# Altium schematic sheet CV210506.SchDoc
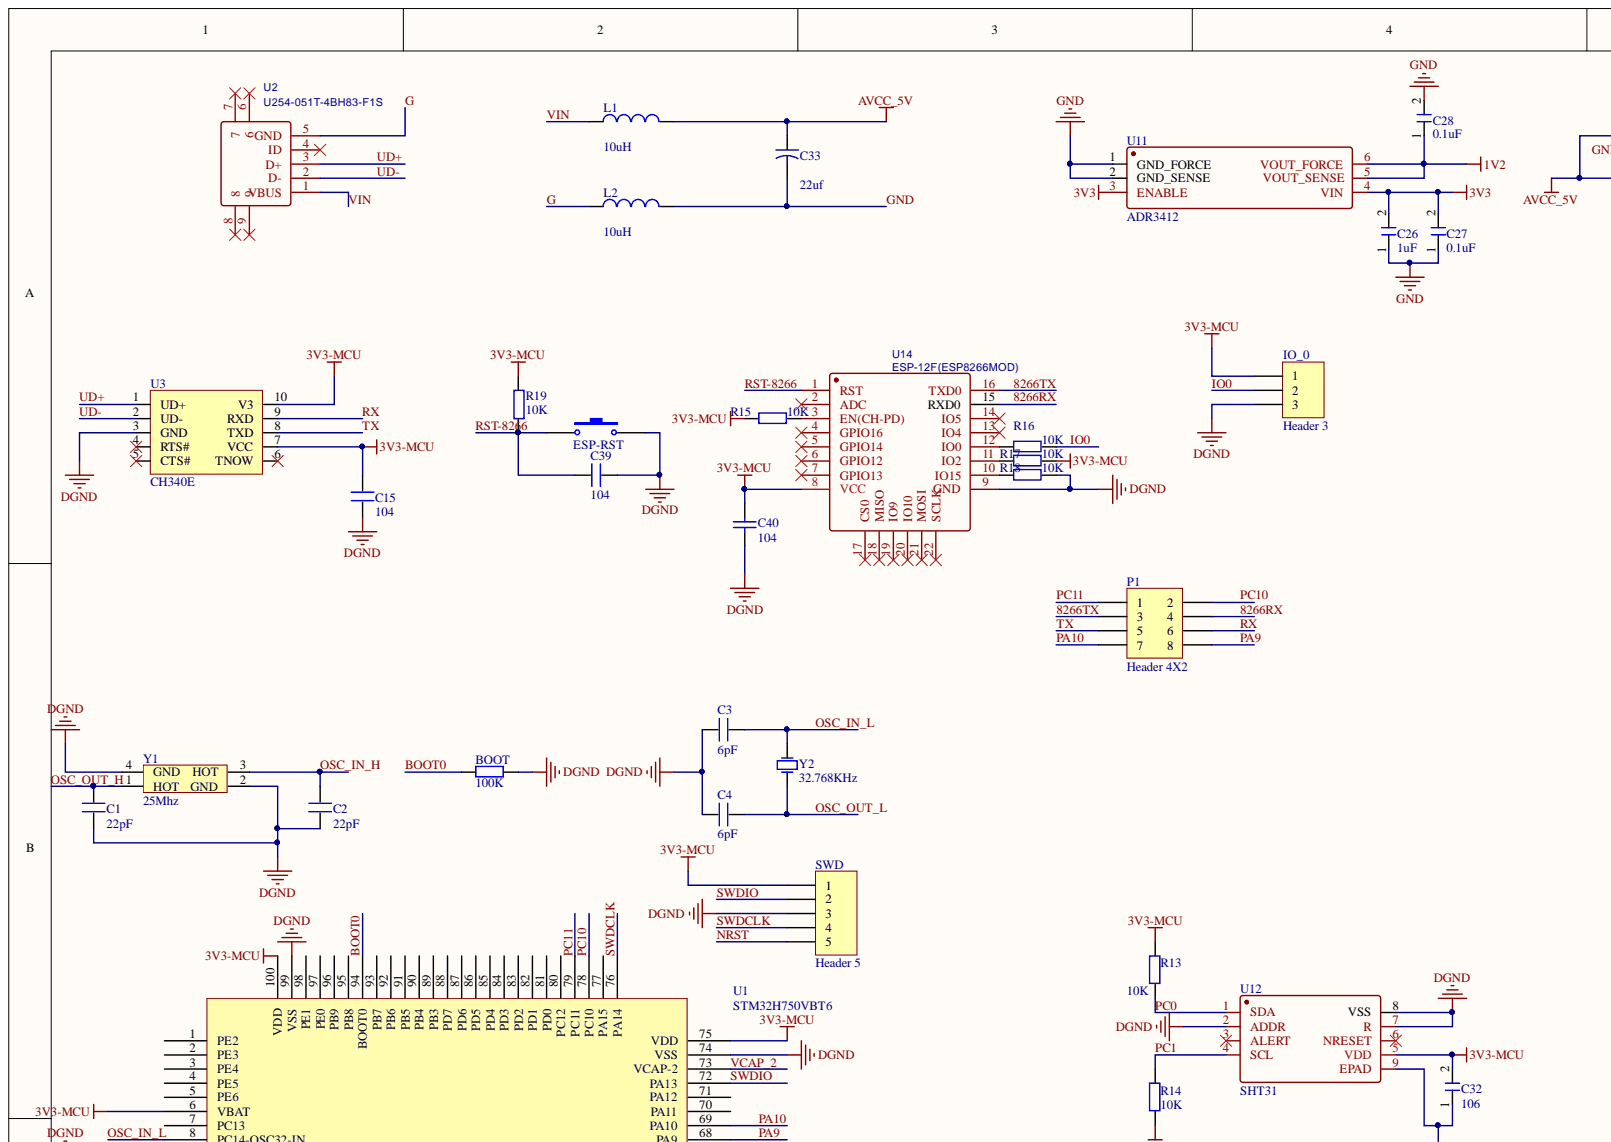
[diagram p0: CV210506.SchDoc, top left]
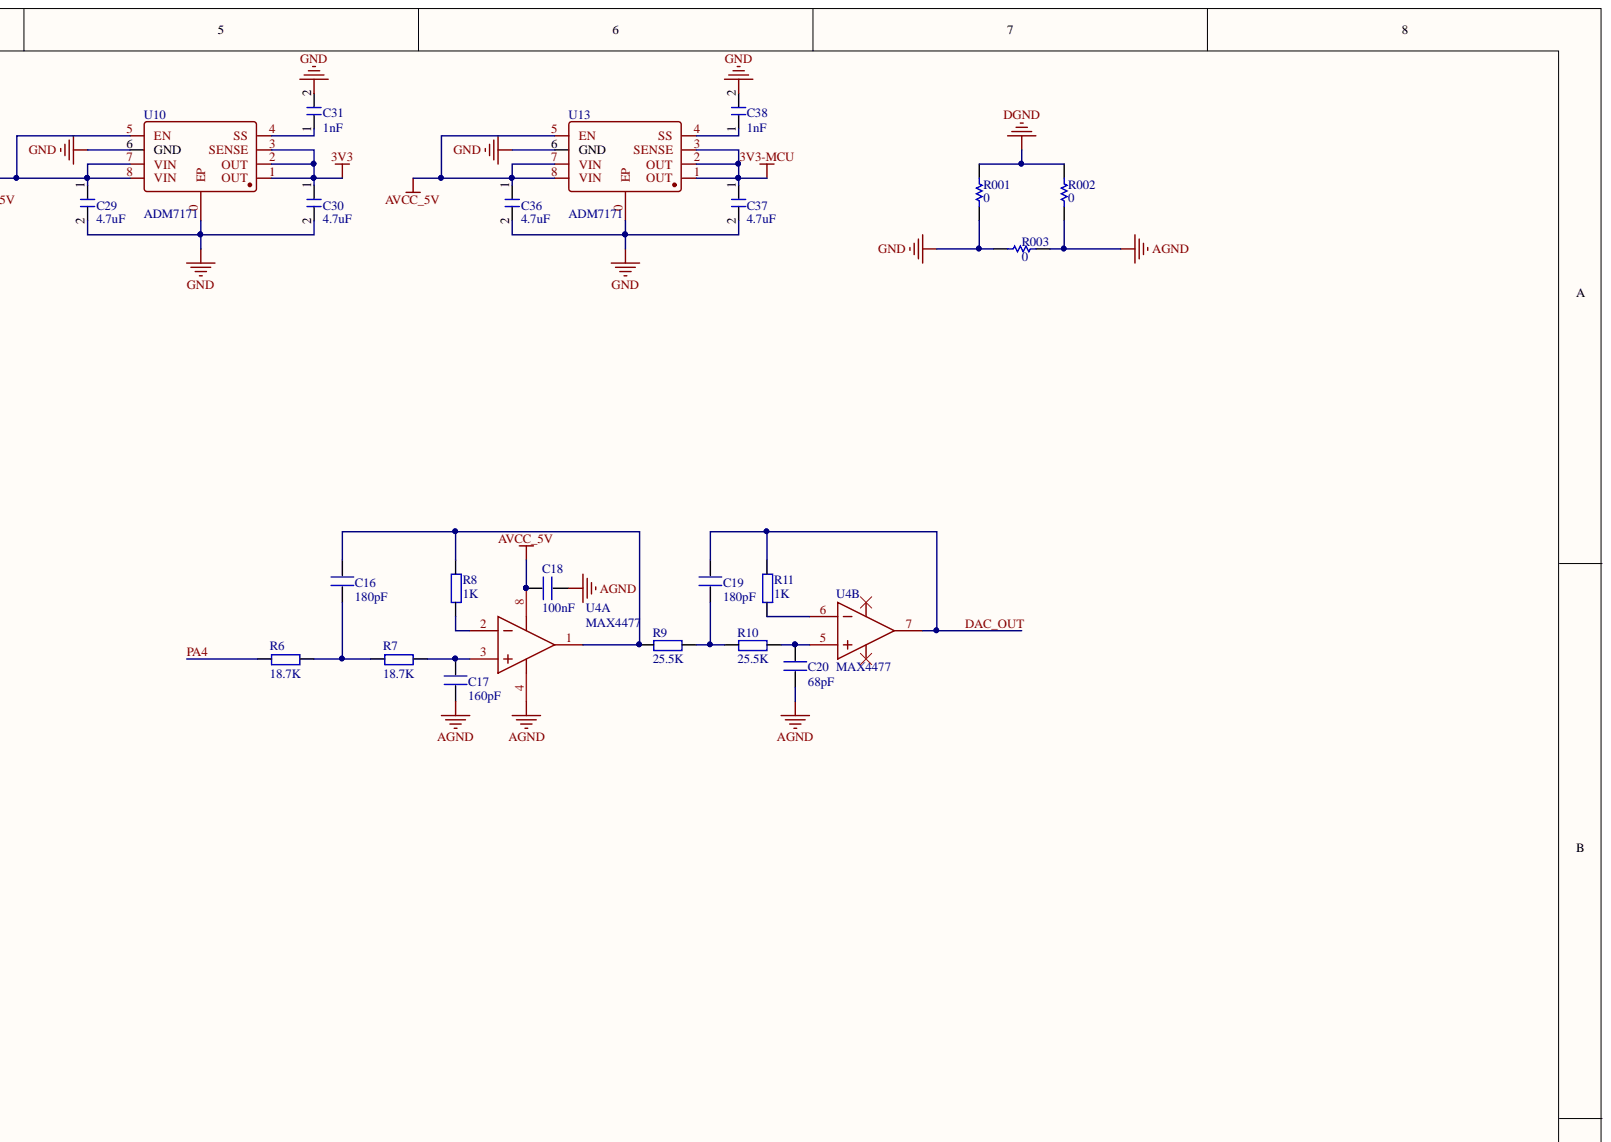
[diagram p1: CV210506.SchDoc, top right]
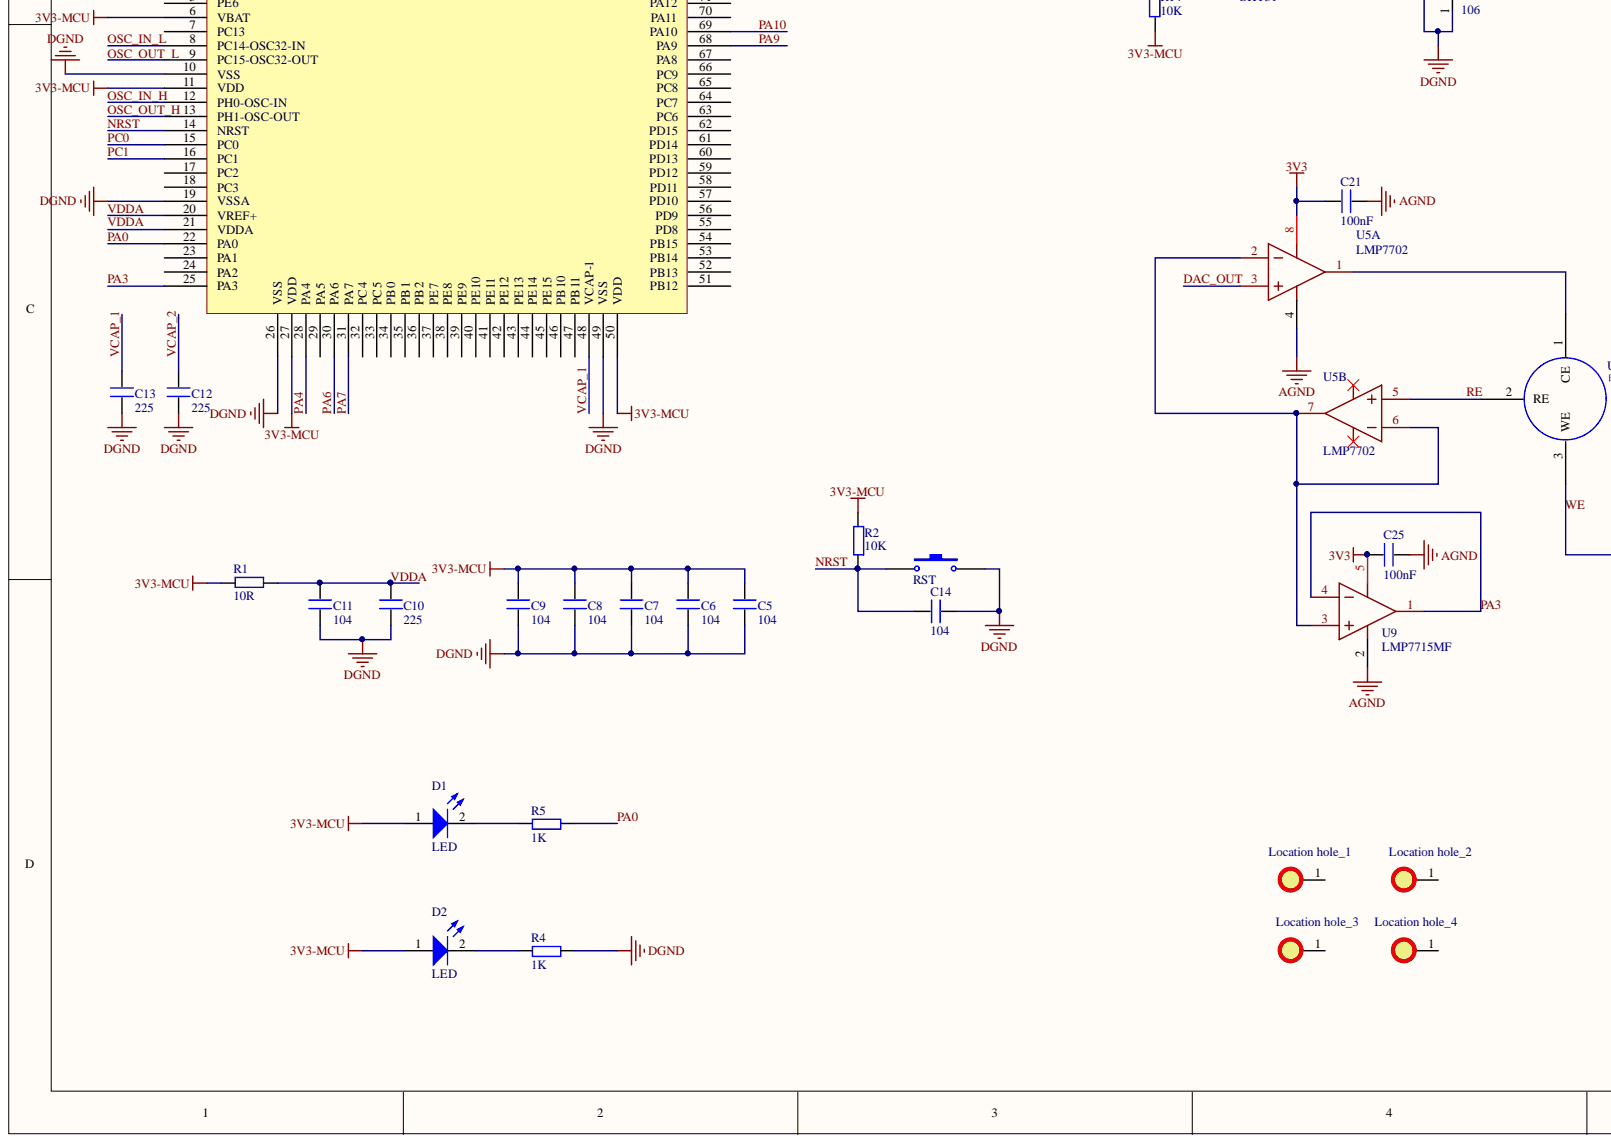
[diagram p2: CV210506.SchDoc, bottom left]
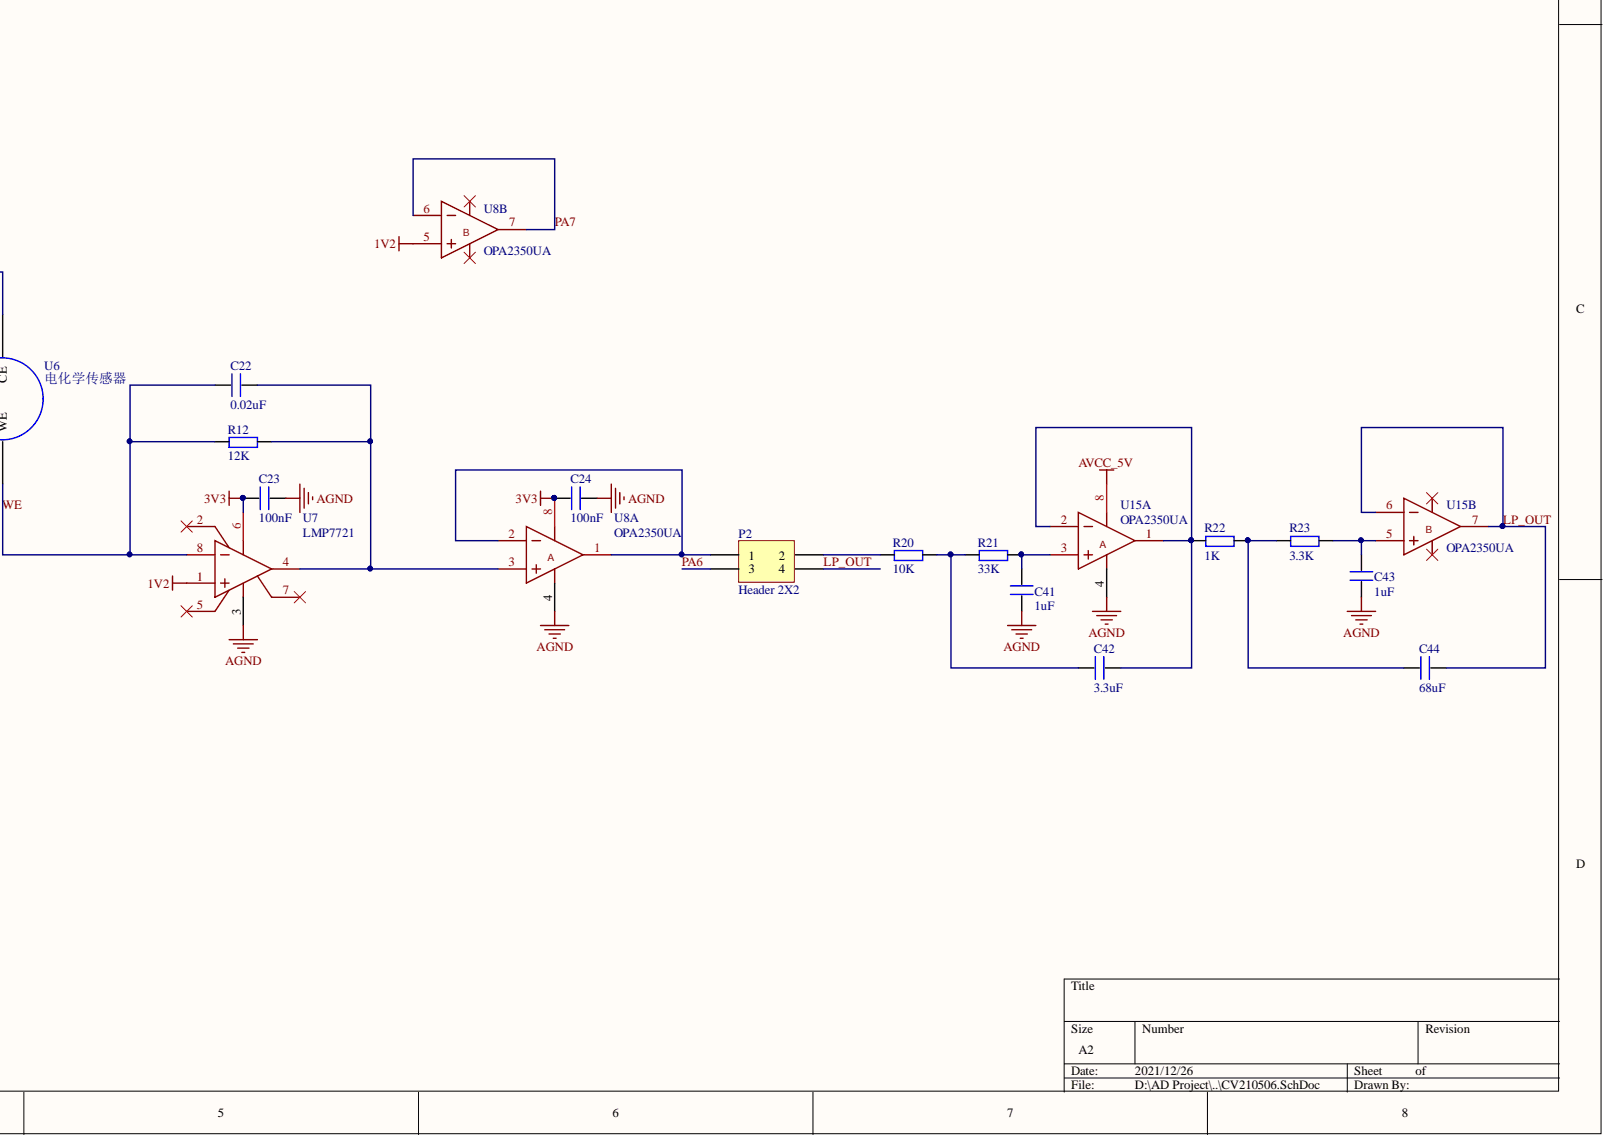
[diagram p3: CV210506.SchDoc, bottom right]

## Parts
BOOT  100K  [RES_0402]
C1  22pF  [CAP_0402]
C2  22pF  [CAP_0402]
C3  6pF  [CAP_0402]
C4  6pF  [CAP_0402]
C5  104  [CAP_0402]
C6  104  [CAP_0402]
C7  104  [CAP_0402]
C8  104  [CAP_0402]
C9  104  [CAP_0402]
C10  225  [CAP_0402]
C11  104  [CAP_0402]
C12  225  [CAP_0402]
C13  225  [CAP_0402]
C14  104  [CAP_0402]
C15  104  [CAP_0402]
C16  180pF  [CAP_0402]
C17  160pF  [CAP_0402]
C18  100nF  [CAP_0402]
C19  180pF  [CAP_0402]
C20  68pF  [CAP_0402]
C21  100nF  [CAP_0402]
C22  0.02uF  [CAP_0402]
C23  100nF  [CAP_0402]
C24  100nF  [CAP_0402]
C25  100nF  [CAP_0402]
C26  1uF  [CAP_0402]
C27  0.1uF  [CAP_0402]
C28  0.1uF  [CAP_0402]
C29  4.7uF  [CAP_0402]
C30  4.7uF  [CAP_0402]
C31  1nF  [CAP_0402]
C32  106  [CAP_0402]
C33  value 22uf  [CAP_0805]  (hidden comment: Cap2)
C36  4.7uF  [CAP_0402]
C37  4.7uF  [CAP_0402]
C38  1nF  [CAP_0402]
C39  104  [CAP_0402]
C40  104  [CAP_0402]
C41  1uF  [CAP_0402]
C42  3.3uF  [CAP_0402]
C43  1uF  [CAP_0402]
C44  68uF  [CAP_0402]
D1  LED  [DIODE_0603]
D2  LED  [DIODE_0603]
ESP-RST  SW-PB  [key3*3]  (value not drawn)
IO_0  Header 3  [HDR1X3]
L1  value 10uH  [RES_1206]  (hidden comment: Inductor)
L2  value 10uH  [RES_1206]  (hidden comment: Inductor)
Location_hole_1  Touch  [kong 3.5]  (value not drawn)
Location_hole_2  Touch  [kong 3.5]  (value not drawn)
Location_hole_3  Touch  [kong 3.5]  (value not drawn)
Location_hole_4  Touch  [kong 3.5]  (value not drawn)
P1  Header 4X2  [HDR2X4]
P2  Header 2X2  [HDR2X2]
R001  0  [RES_0402]
R1  10R  [RES_0402]
R002  0  [RES_0402]
R2  10K  [RES_0402]
R003  0  [RES_0402]
R4  1K  [RES_0402]
R5  1K  [RES_0402]
R6  18.7K  [RES_0402]
R7  18.7K  [RES_0402]
R8  1K  [RES_0402]
R9  25.5K  [RES_0402]
R10  25.5K  [RES_0402]
R11  1K  [RES_0402]
R12  12K  [RES_0805]
R13  10K  [RES_0402]
R14  10K  [RES_0402]
R15  value 10K  [RES_0402]  (hidden comment: Res2)
R16  value 10K  [RES_0402]  (hidden comment: Res2)
R17  value 10K  [RES_0402]  (hidden comment: Res2)
R18  value 10K  [RES_0402]  (hidden comment: Res2)
R19  10K  [RES_0402]
R20  10K  [RES_0402]
R21  33K  [RES_0402]
R22  1K  [RES_0402]
R23  3.3K  [RES_0402]
RST  SW-PB  [key3*3]  (value not drawn)
SWD  Header 5  [HDR1X5]
U1  STM32H750VBT6  [LQFP-100]
U2  U254-051T-4BH83-F1S  [USB]
U3  CH340E  [MSOP-10_L3.0-W3.0-P0.50-LS5.0-BL]
U4  MAX4477  [uMAX-8]  (parts A,B)
U5  LMP7702  [SOIC-8]  (parts A,B)
U6  电化学传感器  [HDR1X3]
U7  LMP7721  [SOIC-8]
U8  OPA2350UA  [VSSOP-8 - 2350]  (parts A,B)
U9  LMP7715MF  [SOT-23-5]
U10  ADM7171  [LFCSP-8]
U11  ADR3412  [SOT-23-6]
U12  SHT31  [DFN-8_EP_3x3mm]
U13  ADM7171  [LFCSP-8]
U14  ESP-12F(ESP8266MOD)  [ESP8266]
U15  OPA2350UA  [VSSOP-8 - 2350]  (parts A,B)
Y1  25Mhz  [SMD 3225]
Y2  32.768KHz  [SMD 3215-2P 32.768K]

## Nets
1V2: C28.1 U7.1 U8.5 U11.5(VOUT_SENSE) U11.6(VOUT_FORCE)
3V3: C21.2 C23.2 C24.2 C25.2 C26.2 C27.2 C30.1 U5.8 U7.6 U8.8 U9.5 U10.1(OUT) U10.2(OUT) U10.3(SENSE) U11.3(ENABLE) U11.4(VIN)
3V3-MCU: C5.2 C6.2 C7.2 C8.2 C9.2 C15.1 C32.2 C37.1 C40.1 D1.1 D2.1 IO_0.1 R1.2 R2.2 R13.2 R14.1 R15.1 R17.2 R19.2 SWD.1 U1.6(VBAT) U1.11(VDD) U1.27(VDD) U1.50 U1.75(VDD) U1.100(VDD) U3.7(VCC) U3.10(V3) U12.5(VDD) U13.1(OUT) U13.2(OUT) U13.3(SENSE) U14.8(VCC)
AGND: C17.1 C18.1 C20.1 C21.1 C23.1 C24.1 C25.1 C41.1 C43.1 R002.2 R003.1 U4.4 U5.4 U7.3 U8.4 U9.2 U15.4
AVCC_5V: C18.2 C29.1 C33.1 C36.1 L1.2 U4.8 U10.5(EN) U10.7(VIN) U10.8(VIN) U13.5(EN) U13.7(VIN) U13.8(VIN) U15.8
DGND: BOOT.2 C1.1 C2.1 C3.1 C4.1 C5.1 C6.1 C7.1 C8.1 C9.1 C10.1 C11.1 C12.1 C13.1 C14.2 C15.2 C32.1 C39.2 C40.2 ESP-RST.2 IO_0.3 R001.1 R002.1 R4.2 R18.2 RST.2 SWD.3 U1.10(VSS) U1.19(VSSA) U1.26(VSS) U1.49(VSS) U1.74(VSS) U1.99(VSS) U3.3(GND) U12.2(ADDR) U12.7(R) U12.8(VSS) U12.9(EPAD) U14.9(GND) Y1.2(GND) Y1.4(GND)
8266RX: P1.4 U14.15(RXD0)
8266TX: P1.3 U14.16(TXD0)
BOOT0: BOOT.1 U1.94(BOOT0)
DAC_OUT: C19.2 R11.2 U4.7 U5.3
G: L2.1 U2.5(GND)
GND: C26.1 C27.1 C28.2 C29.2 C30.2 C31.2 C33.2 C36.2 C37.2 C38.2 L2.2 R001.2 R003.2 U10.0(EP) U10.6(GND) U11.1(GND_FORCE) U11.2(GND_SENSE) U13.0(EP) U13.6(GND)
IO0: IO_0.2 R16.2
LP_OUT: C44.1 P2.4 U15.6 U15.7
NRST: C14.1 R2.1 RST.1 SWD.5 U1.14(NRST)
OSC_IN_H: C2.2 U1.12(PH0-OSC-IN) Y1.3(HOT)
OSC_IN_L: C3.2 U1.8(PC14-OSC32-IN) Y2.2
OSC_OUT_H: C1.2 U1.13(PH1-OSC-OUT) Y1.1(HOT)
OSC_OUT_L: C4.2 U1.9(PC15-OSC32-OUT) Y2.1
PA0: R5.2 U1.22(PA0)
PA3: U1.25(PA3) U9.1 U9.4
PA4: R6.1 U1.28(PA4)
PA6: P2.3 U1.30(PA6)
PA7: U1.31(PA7) U8.6 U8.7
PA9: P1.8 U1.68(PA9)
PA10: P1.7 U1.69(PA10)
PC0: R13.1 U1.15(PC0) U12.1(SDA)
PC1: R14.2 U1.16(PC1) U12.4(SCL)
PC10: P1.2 U1.78(PC10)
PC11: P1.1 U1.79(PC11)
RE: U5.5 U6.2
RST-8266: C39.1 ESP-RST.1 R19.1 U14.1(RST)
RX: P1.6 U3.9(RXD)
SWDCLK: SWD.4 U1.76
SWDIO: SWD.2 U1.72(PA13)
TX: P1.5 U3.8(TXD)
UD+: U2.3(D+) U3.1(UD+)
UD-: U2.2(D-) U3.2(UD-)
VCAP_1: C13.2 U1.48(VCAP-1)
VCAP_2: C12.2 U1.73(VCAP-2)
VDDA: C10.2 C11.2 R1.1 U1.20(VREF+) U1.21(VDDA)
VIN: L1.1 U2.1(VBUS)
WE: C22.2 R12.1 U6.3 U7.8
NetC16_1: C16.1 R6.2 R7.1
NetC16_2: C16.2 R8.2 R9.1 U4.1
NetC17_2: C17.2 R7.2 U4.3
NetC19_1: C19.1 R9.2 R10.1
NetC20_2: C20.2 R10.2 U4.5
NetC22_1: C22.1 R12.2 U7.4 U8.3
NetC31_1: C31.1 U10.4(SS)
NetC38_1: C38.1 U13.4(SS)
NetC41_2: C41.2 R21.2 U15.3
NetC42_1: C42.1 R22.1 U15.1 U15.2
NetC42_2: C42.2 R20.2 R21.1
NetC43_2: C43.2 R23.2 U15.5
NetC44_2: C44.2 R22.2 R23.1
NetD1_2: D1.2 R5.1
NetD2_2: D2.2 R4.1
NetP2_1: P2.1 U8.1 U8.2
NetP2_2: P2.2 R20.1
NetR8_1: R8.1 U4.2
NetR11_1: R11.1 U4.6
NetR15_2: R15.2 U14.3(EN(CH-PD))
NetR16_1: R16.1 U14.12(IO0)
NetR17_1: R17.1 U14.11(IO2)
NetR18_1: R18.1 U14.10(IO15)
NetU5_1: U5.1 U6.1
NetU5_2: U5.2 U5.6 U5.7 U9.3

Not connected: Location_hole_1 1; Location_hole_2 1; Location_hole_3 1; Location_hole_4 1; U1 1(PE2) 2(PE3) 3(PE4) 4(PE5) 5(PE6) 7(PC13) 17(PC2) 18(PC3) 23(PA1) 24(PA2) 29(PA5) 32(PC4) 33(PC5) 34(PB0) 35(PB1) 36(PB2) 37(PE7) 38(PE8) 39(PE9) 40(PE10) 41(PE11) 42(PE12) 43(PE13) 44(PE14) 45(PE15) 46(PB10) 47(PB11) 51(PB12) 52(PB13) 53(PB14) 54(PB15) 55(PD8) 56(PD9) 57(PD10) 58(PD11) 59(PD12) 60(PD13) 61(PD14) 62(PD15) 63(PC6) 64(PC7) 65(PC8) 66(PC9) 67(PA8) 70(PA11) 71(PA12) 77(PA15) 80(PC12) 81(PD0) 82(PD1) 83(PD2) 84(PD3) 85(PD4) 86(PD5) 87(PD6) 88(PD7) 89(PB3) 90(PB4) 91(PB5) 92(PB6) 93(PB7) 95(PB8) 96(PB9) 97(PE0) 98(PE1); U2 4(ID) 6 7 8 9; U3 4(RTS#) 5(CTS#) 6(TNOW); U7 2 5 7; U12 3(ALERT) 6(NRESET); U14 2(ADC) 4(GPIO16) 5(GPIO14) 6(GPIO12) 7(GPIO13) 13(IO4) 14(IO5) 17(CS0) 18(MISO) 19(IO9) 20(IO10) 21(MOSI) 22(SCLK)

## Library data of the parts
BOOT R13-R18 = Res2: Resistor; Component Kind: Standard; LatestRevisionDate: 17-Jul-2002; LatestRevisionNote: Re-released for DXP Platform.; PackageReference: AXIAL-0.4; Published: 8-Jun-2000; Signal Integrity: Res; Simulation: RESISTOR; Publisher: Altium Limited
  BOOT: Library Name: Miscellaneous Devices.IntLib; PackageDescription: Axial Device, Thru-Hole; 2 Leads; 0.4 in Pin Spacing; Value: 100K
  R13 R14: Value: 10K
  R13-R18: Library Name: myintlib.SchLib
C1-C25 C39 C41-C44 = 电容: Component Kind: Standard; Library Name: STM32H743.SchLib
C26-C32 C36-C38 = Cap: Component Kind: Standard; Library Name: WzyControl1.SchLib
C33 = Cap2: Capacitor; Component Kind: Standard; Library Name: Miscellaneous Devices.IntLib; PackageDescription: Capacitor; 2 Leads; PackageReference: CAPR5-4X5; Published: 23-Sep-2002; Signal Integrity: Cap; Simulation: CAP; Publisher: Altium Limited
C40 = Cap: Capacitor; Component Kind: Standard; LatestRevisionDate: 17-Jul-2002; LatestRevisionNote: Re-released for DXP Platform.; Library Name: Miscellaneous Devices.IntLib; PackageDescription: Radial Cap, Thru-Hole; 2 Leads; 0.3 in Pin Spacing; PackageReference: RAD-0.3; Published: 8-Jun-2000; Signal Integrity: Cap; Simulation: CAP; Publisher: Altium Limited
ESP-RST RST = SW-PB: Switch; Component Kind: Standard; LatestRevisionDate: 17-Jul-2002; LatestRevisionNote: Re-released for DXP Platform.; Library Name: STM32H743.SchLib; PackageDescription: Switch; 2 Leads; PackageReference: SPST-2; Published: 24-Mar-1999; Publisher: Altium Limited
IO_0 = Header 3: Header, 3-Pin; Component Kind: Standard; LatestRevisionDate: 17-Jul-2002; LatestRevisionNote: Re-released for DXP Platform.; Library Name: Miscellaneous Connectors.IntLib; Signal Integrity: Connector; Publisher: Altium Limited
L1 L2 = Inductor: Code_IEC: 1005; Code_JEITA: 0402; Component Kind: Standard; LatestRevisionDate: 29-May-2009; LatestRevisionNote: IPC-7351 Footprint Added.; Library Name: Miscellaneous Devices.IntLib; PackageDescription: Chip Inductor; PackageReference: 0402-A; Published: 8-Jun-2000; Signal Integrity: Inductor; Simulation: INDUCTOR; Publisher: Altium Limited
  L2: Description: Inductor
P1 = Header 4X2: Header, 4-Pin, Dual row; Component Kind: Standard; LatestRevisionDate: 17-Jul-2002; LatestRevisionNote: Re-released for DXP Platform.; Library Name: Miscellaneous Connectors.IntLib; Signal Integrity: Connector; Publisher: Altium Limited
P2 = Header 2X2: Header, 2-Pin, Dual row; Component Kind: Standard; LatestRevisionDate: 17-Jul-2002; LatestRevisionNote: Re-released for DXP Platform.; Library Name: Miscellaneous Connectors.IntLib; Signal Integrity: Connector; Publisher: Altium Limited
R001 R002 R003 = Res1: Resistor; Component Kind: Standard; Library Name: Miscellaneous Devices.IntLib; Value: 0
R1 R2 R4-R12 R19-R23 = 电阻: Component Kind: Standard; Library Name: STM32H743.SchLib
SWD = Header 5: Header, 5-Pin; Component Kind: Standard; LatestRevisionDate: 17-Jul-2002; LatestRevisionNote: Re-released for DXP Platform.; Library Name: Miscellaneous Connectors.IntLib; Signal Integrity: Connector; Publisher: Altium Limited
U2 = U254-051T-4BH83-F1S: BOM_Manufacturer: XKB Enterprise; Contributor: LCSC; BOM_Manufacturer Part: U254-051T-4BH83-F1S; BOM_Supplier: LCSC; BOM_Supplier Part: C397452; Component Kind: Standard; spicePre: U; spiceSymbolName: U254-051T-4BH83-F1S
U3 = CH340-E: Component Kind: Standard; Library Name: WzyControl1.SchLib
U10 U13 = ADM7172: Component Kind: Standard; Library Name: WzyControl1.SchLib
U14 = ESP-12F(ESP8266MOD): BOM_Manufacturer: Ai-Thinker; BOM_Manufacturer Part: ESP-12F(ESP8266MOD); BOM_Supplier: LCSC; BOM_Supplier Part: C82891; Component Kind: Standard; spicePre: U; spiceSymbolName: ESP-12F(ESP8266MOD)
Y1 = XTAL_3225: 3225贴片无源晶振; Component Kind: Standard; Library Name: STM32H743核心板封装库.IntLib
Y2 = XTAL: 普通晶振; Component Kind: Standard; LatestRevisionDate: 17-Jul-2002; LatestRevisionNote: Re-released for DXP Platform.; Library Name: STM32H743核心板封装库.IntLib; Published: 8-Jun-2000; Publisher: Altium Limited
D1 D2 = LED: Component Kind: Standard; Description: LED; Library Name: STM32H743.SchLib
Location_hole_1-Location_hole_4 = Touch: Component Kind: Standard; Library Name: Sean.SchLib
U1 = STM32H750VBT6: Component Kind: Standard; Library Name: STM32H743.SchLib
U4 = MAX4477: Component Kind: Standard; Library Name: WzyControl1.SchLib
U5 = LMP7702: Component Kind: Standard; Library Name: WzyControl1.SchLib
U6 = 电化学传感器: Component Kind: Standard; Library Name: WzyControl1.SchLib
U7 = LMP7721: Component Kind: Standard; Library Name: WzyControl1.SchLib
U8 U15 = OPA2350UA: Component Kind: Standard; Library Name: WzyControl1.SchLib
U9 = LMP7715MF: Component Kind: Standard; Library Name: WzyControl1.SchLib
U11 = ADR3412: Component Kind: Standard; Library Name: WzyControl1.SchLib
U12 = SHT31: Component Kind: Standard; Library Name: WzyControl1.SchLib
